# Altium schematic sheet Sheet2.SchDoc(Sheet2)
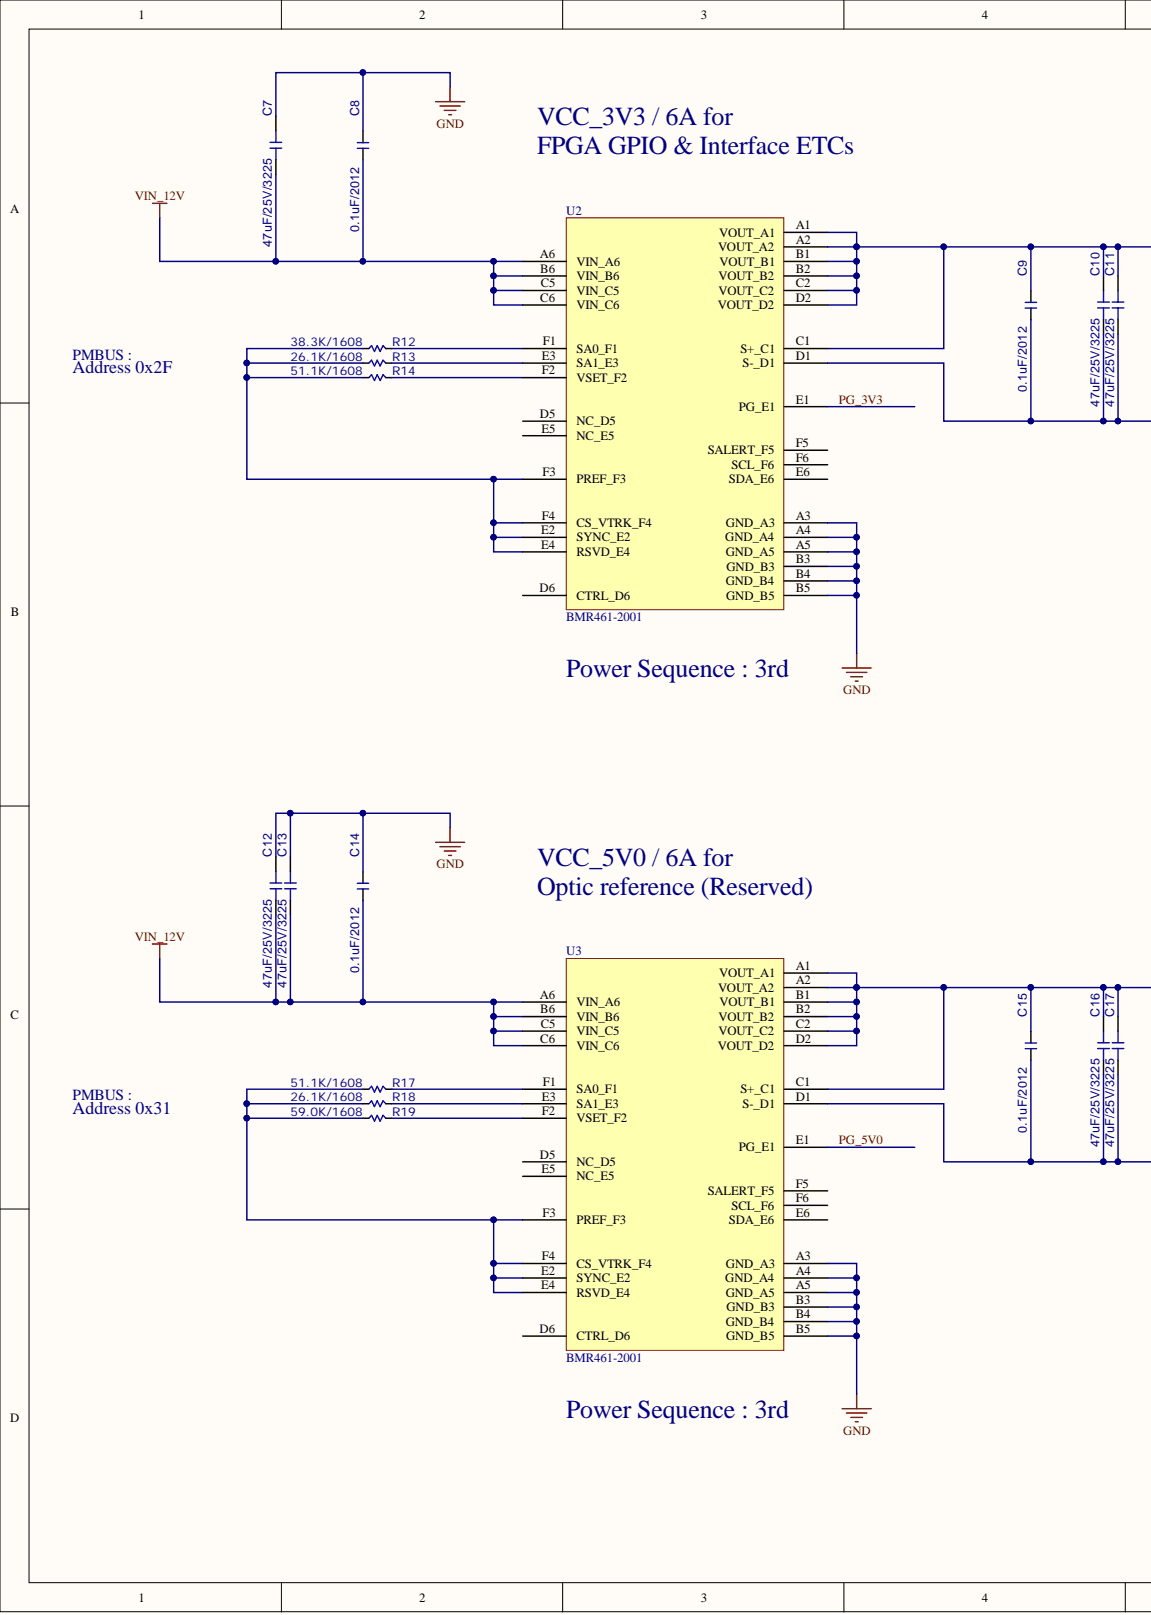
[diagram p0: Sheet2.SchDoc, left]
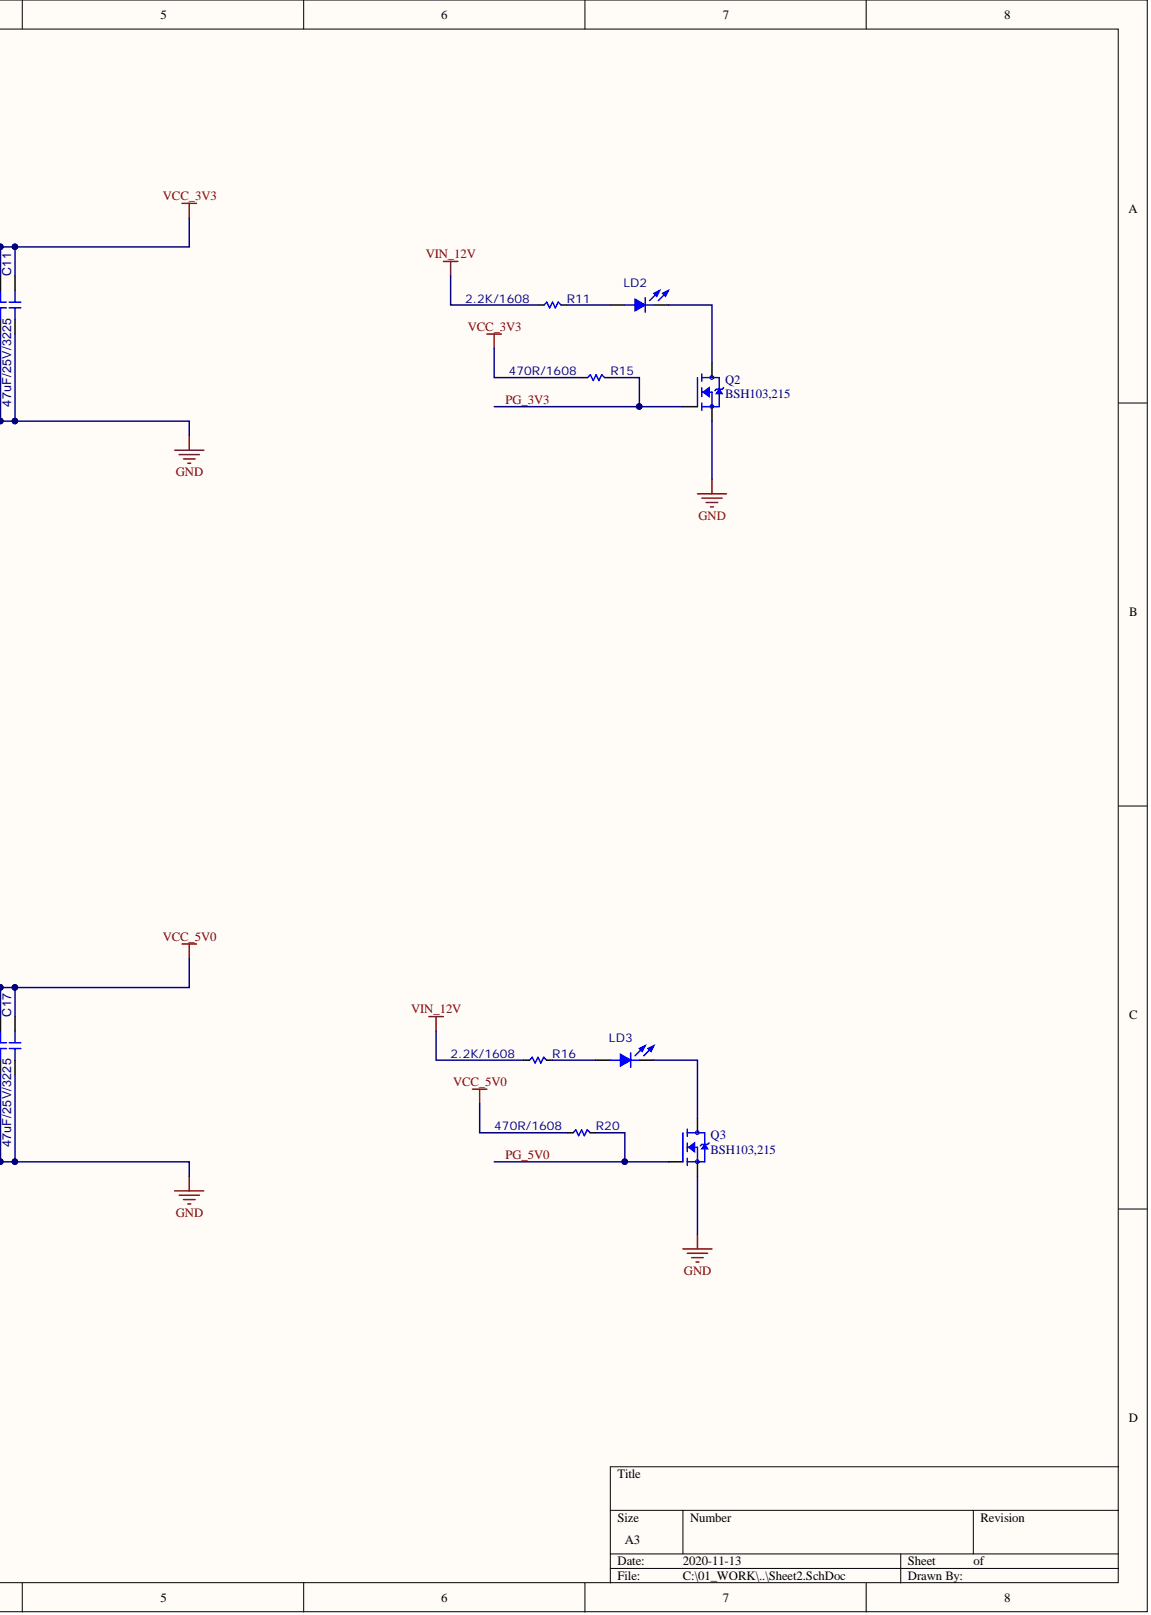
[diagram p1: Sheet2.SchDoc, right]

## Parts
C7  47uF/25V/3225  [C3225]
C8  0.1uF/2012  [C2012]
C9  0.1uF/2012  [C2012]
C10  47uF/25V/3225  [C3225]
C11  47uF/25V/3225  [C3225]
C12  47uF/25V/3225  [C3225]
C13  47uF/25V/3225  [C3225]
C14  0.1uF/2012  [C2012]
C15  0.1uF/2012  [C2012]
C16  47uF/25V/3225  [C3225]
C17  47uF/25V/3225  [C3225]
LD2  (no value)  [LED1608]
LD3  (no value)  [LED1608]
Q2  BSH103,215  [SOT23]
Q3  BSH103,215  [SOT23]
R11  2.2K/1608  [R1608]
R12  38.3K/1608  [R1608]
R13  26.1K/1608  [R1608]
R14  51.1K/1608  [R1608]
R15  470R/1608  [R1608]
R16  2.2K/1608  [R1608]
R17  51.1K/1608  [R1608]
R18  26.1K/1608  [R1608]
R19  59.0K/1608  [R1608]
R20  470R/1608  [R1608]
U2  BMR461-2001  [BGA36C200P6X6_1220X1220X800]
U3  BMR461-2001  [BGA36C200P6X6_1220X1220X800]

## Nets
GND: C7.2 C8.2 C9.1 C10.1 C11.1 C12.2 C13.2 C14.2 C15.1 C16.1 C17.1 Q2.2 Q3.2 U2.A3(GND_A3) U2.A4(GND_A4) U2.A5(GND_A5) U2.B3(GND_B3) U2.B4(GND_B4) U2.B5(GND_B5) U2.D1(S-_D1) U3.A3(GND_A3) U3.A4(GND_A4) U3.A5(GND_A5) U3.B3(GND_B3) U3.B4(GND_B4) U3.B5(GND_B5) U3.D1(S-_D1)
VCC_3V3: C9.2 C10.2 C11.2 R15.1 U2.A1(VOUT_A1) U2.A2(VOUT_A2) U2.B1(VOUT_B1) U2.B2(VOUT_B2) U2.C1(S+_C1) U2.C2(VOUT_C2) U2.D2(VOUT_D2)
VCC_5V0: C15.2 C16.2 C17.2 R20.1 U3.A1(VOUT_A1) U3.A2(VOUT_A2) U3.B1(VOUT_B1) U3.B2(VOUT_B2) U3.C1(S+_C1) U3.C2(VOUT_C2) U3.D2(VOUT_D2)
VIN_12V: C7.1 C8.1 C12.1 C13.1 C14.1 R11.1 R16.1 U2.A6(VIN_A6) U2.B6(VIN_B6) U2.C5(VIN_C5) U2.C6(VIN_C6) U3.A6(VIN_A6) U3.B6(VIN_B6) U3.C5(VIN_C5) U3.C6(VIN_C6)
PG_3V3: Q2.1 R15.2 U2.E1(PG_E1)
PG_5V0: Q3.1 R20.2 U3.E1(PG_E1)
NetLD2_1: LD2.1 R11.2
NetLD2_2: LD2.2 Q2.3
NetLD3_1: LD3.1 R16.2
NetLD3_2: LD3.2 Q3.3
NetR12_1: R12.1 R13.1 R14.1 U2.E2(SYNC_E2) U2.E4(RSVD_E4) U2.F3(PREF_F3) U2.F4(CS_VTRK_F4)
NetR12_2: R12.2 U2.F1(SA0_F1)
NetR13_2: R13.2 U2.E3(SA1_E3)
NetR14_2: R14.2 U2.F2(VSET_F2)
NetR17_1: R17.1 R18.1 R19.1 U3.E2(SYNC_E2) U3.E4(RSVD_E4) U3.F3(PREF_F3) U3.F4(CS_VTRK_F4)
NetR17_2: R17.2 U3.F1(SA0_F1)
NetR18_2: R18.2 U3.E3(SA1_E3)
NetR19_2: R19.2 U3.F2(VSET_F2)

Not connected: U2 D5(NC_D5) D6(CTRL_D6) E5(NC_E5) E6(SDA_E6) F5(SALERT_F5) F6(SCL_F6); U3 D5(NC_D5) D6(CTRL_D6) E5(NC_E5) E6(SDA_E6) F5(SALERT_F5) F6(SCL_F6)

## Library data of the parts
C7 C10-C13 C16 C17 = C3225: Chip Capacitor Ceramic; Value: uF; Component Kind: Standard; Library Name: zerom_mm.SchLib
C8 C9 C14 C15 = C2012: Chip Capacitor Ceramic; Value: uF; Component Kind: Standard; Library Name: zerom_mm.SchLib
LD2 LD3 = LED1608: Chip LED Lamp; Component Kind: Standard; Library Name: zerom_mm.SchLib
Q2 Q3 = BSH103,215: N-Channel 30V 850mA _Ta_ 540mW _Ta_ Surface Mount TO-236AB; MF: Nexperia USA; SnapEDA_Link: https://www.snapeda.com/parts/BSH103,215/Nexperia%20USA%20Inc./view-part/624233/?ref=snap; Price: None; Package: SOT-23-3 Nexperia; MP: BSH103,215; Library Name: BSH103_215.IntLib; Component Kind: Standard; Availability: Unavailable
R11-R20 = R1608: Chip Resistor; Value: OHM; Component Kind: Standard; Library Name: zerom_mm.SchLib
U2 U3 = BMR461-2001: Library Name: BMR461-2001.SchLib; Component Kind: Standard
